# Altium schematic sheet Sheet1.SchDoc(Sheet1)
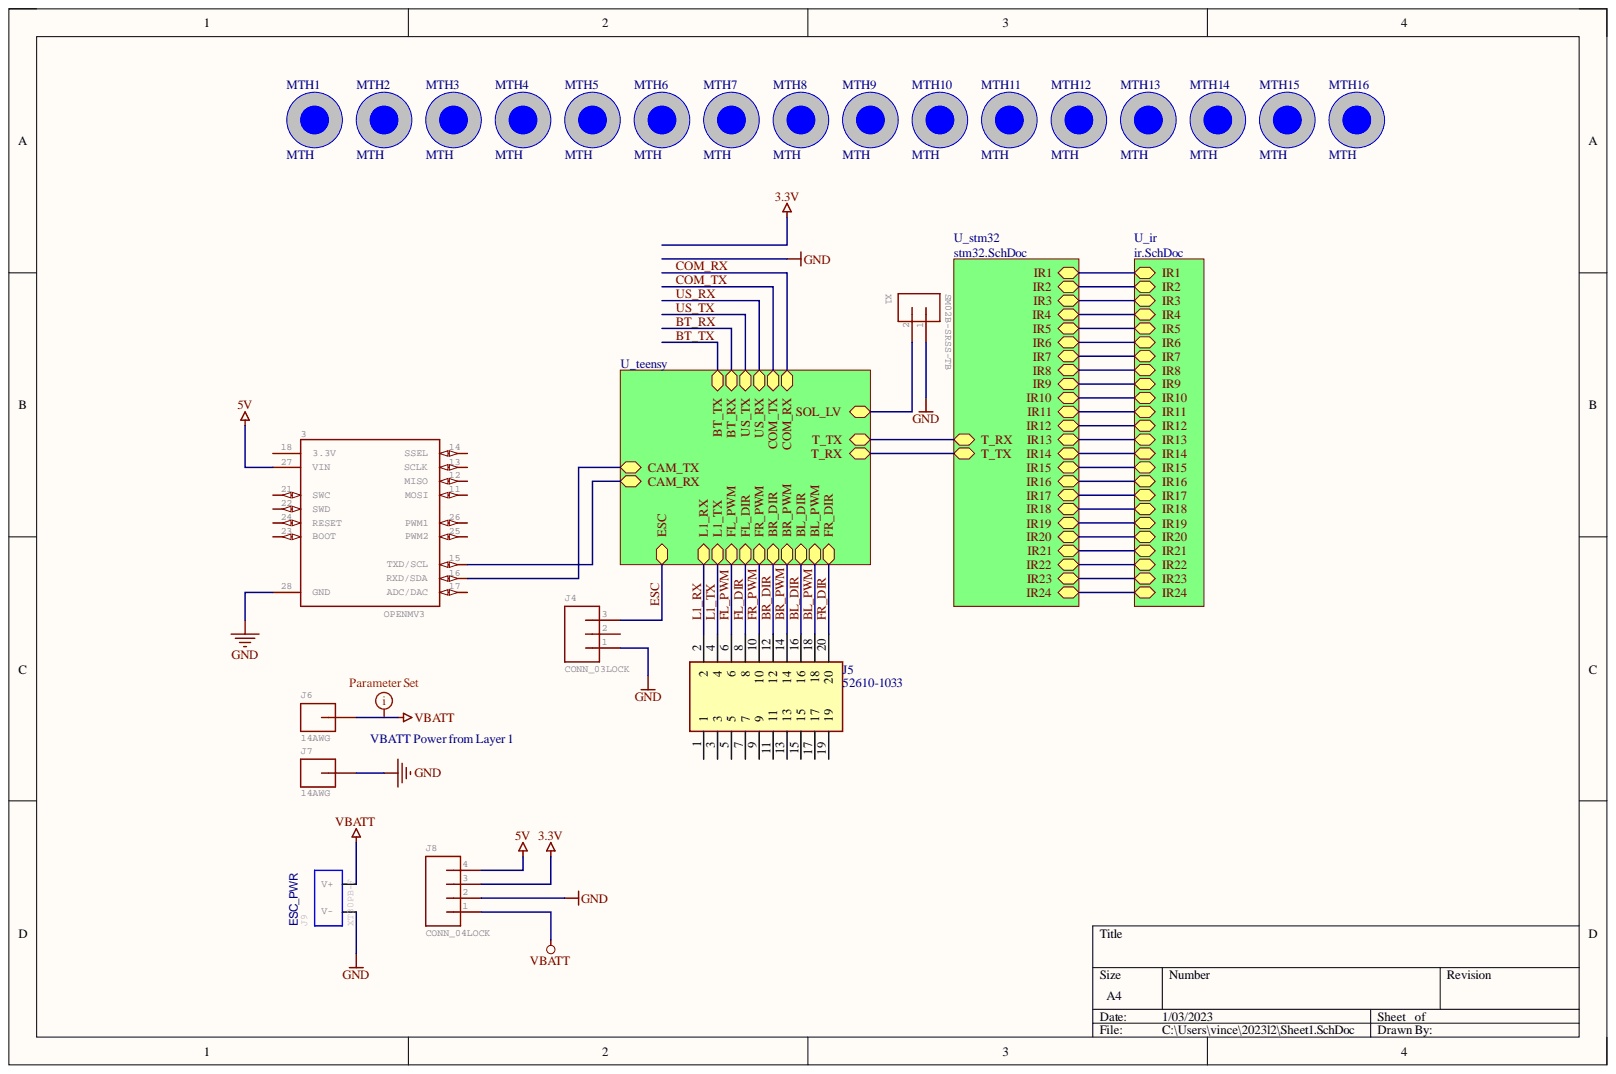
[diagram p0: Sheet1.SchDoc]

## Parts
3  OPENMV3  [OPENMV3]
J4  CONN_03LOCK  [1X03_LOCK]  (value not drawn)
J5  52610-1033  [526101033]
J6  14AWG  [14AWG]
J7  14AWG  [14AWG]
J8  CONN_04LOCK  [1X04_LOCK]  (value not drawn)
J9  XT30PB-F  [XT30PB-F]
MTH1  MTH  [New footprint 1]
MTH2  MTH  [New footprint 1]
MTH3  MTH  [New footprint 1]
MTH4  MTH  [New footprint 1]
MTH5  MTH  [New footprint 1]
MTH6  MTH  [New footprint 1]
MTH7  MTH  [New footprint 1]
MTH8  MTH  [New footprint 1]
MTH9  MTH  [New footprint 1]
MTH10  MTH  [New footprint 1]
MTH11  MTH  [New footprint 1]
MTH12  MTH  [New footprint 1]
MTH13  MTH  [New footprint 1]
MTH14  MTH  [New footprint 1]
MTH15  MTH  [New footprint 1]
MTH16  MTH  [New footprint 1]
X1  SM02B-SRSS-TB  [SM02B-SRSS-TB]

## Nets
3.3V: J8.3
5V: 3.27(VIN) J8.4
GND: 3.28(GND) J4.1 J7.P$1 J8.2 J9.- X1.1
VBATT: J6.P$1 J8.1 J9.+
BL_DIR: J5.16
BL_PWM: J5.18
BR_DIR: J5.12
BR_PWM: J5.14
ESC: J4.3
FL_DIR: J5.8
FL_PWM: J5.6
FR_DIR: J5.20
FR_PWM: J5.10
L1_RX: J5.2
L1_TX: J5.4
Net3_11: 3.11(MOSI)
Net3_12: 3.12(MISO)
Net3_13: 3.13(SCLK)
Net3_14: 3.14(SSEL)
Net3_15: 3.15(TXD/SCL)
Net3_16: 3.16(RXD/SDA)
Net3_17: 3.17(ADC/DAC)
Net3_18: 3.18(3.3V)
Net3_21: 3.21(SWC)
Net3_22: 3.22(SWD)
Net3_23: 3.23(BOOT)
Net3_24: 3.24(RESET)
Net3_25: 3.25(PWM2)
Net3_26: 3.26(PWM1)
NetJ4_2: J4.2
NetJ5_1: J5.1
NetJ5_3: J5.3
NetJ5_5: J5.5
NetJ5_7: J5.7
NetJ5_9: J5.9
NetJ5_11: J5.11
NetJ5_13: J5.13
NetJ5_15: J5.15
NetJ5_17: J5.17
NetJ5_19: J5.19
NetX1_2: X1.2
BT_RX:
BT_TX:
COM_RX:
COM_TX:
IR1:
IR2:
IR3:
IR4:
IR5:
IR6:
IR7:
IR8:
IR9:
IR10:
IR11:
IR12:
IR13:
IR14:
IR15:
IR16:
IR17:
IR18:
IR19:
IR20:
IR21:
IR22:
IR23:
IR24:
T_RX:
US_RX:
US_TX:

## Library data of the parts
3 = CMP-085-000000-11: Camera; GateName_1: G$1; Component Kind: Standard; Component Type: Standard; Design Item ID: OPENMV3; DeviceSetName: OPENMV3; LibraryName: openmv; Package: OPENMV3; Pin Count: 16; SymbolName_1: OPENMV3; Library Name: Hwa Chong Institution
J4 = CMP-027-000002-2: DeviceName: LOCK; GateName_1: J$1; Component Kind: Standard; Component Type: Standard; Design Item ID: CONN_03LOCK; DeviceSetName: CONN_03; LibraryName: SparkFun-Connectors; Package: 1X03_LOCK; Pin Count: 3; Source: SparkFun-Connectors.SCHLIB; SymbolName_1: CONN_03; Library Name: Hwa Chong Institution
J5 = CMP-096-000003-1: FFC & FPC Connectors 1.0 FPC ZIF ST 10Ckt Sn-Ag-Bi; Manufacturer_Name: Molex; Component Kind: Standard; Datasheet Link: https://www.mouser.com/datasheet/2/276/0526101033_FFC_FPC_CONNECTORS-294979.pdf; Design Item ID: 52610-1033; Height: 7.45mm; Manufacturer_Part_Number: 52610-1033; Mouser Part Number: 538-52610-1033; Mouser Price/Stock: https://www.mouser.co.uk/ProductDetail/Molex/52610-1033?qs=rw2VfpiI%2FsPpjB%252BOP20huA%3D%3D; Source: SamacSys.SchLib; Library Name: Hwa Chong Institution
J6 J7 = CMP-080-000001-1: GateName_1: G$1; SymbolName_1: CONN_01; Component Kind: Standard; Component Type: Standard; Design Item ID: 14AWG; DeviceSetName: 14AWG; LibraryName: MY-LIBRARY; Package: 14AWG; Pin Count: 1; Source: MY-LIBRARY.SCHLIB; Library Name: Hwa Chong Institution
J8 = CMP-027-000001-1: DeviceName: LOCK; GateName_1: J1; PROD_ID: CONN-09696; Component Kind: Standard; Component Type: Standard; Design Item ID: CONN_04LOCK; DeviceSetName: CONN_04; LibraryName: SparkFun-Connectors; Package: 1X04_LOCK; Pin Count: 4; Source: SparkFun-Connectors.SCHLIB; SymbolName_1: CONN_04; Library Name: Hwa Chong Institution
J9 = CMP-071-000005-3: XT30; DeviceName: PB-F; GateName_1: G$1; SymbolName_1: POWER_CONSORT; Component Kind: Standard; Component Type: Standard; Design Item ID: XT30PB-F; DeviceSetName: XT30; LibraryName: JH; Package: XT30PB-F; Pin Count: 2; Source: JH.SCHLIB; Library Name: Hwa Chong Institution
MTH1-MTH16 = CMP-00028-00002-1: Normal M3 MTH; Component Kind: Standard; Library Name: Hwa Chong Institution
X1 = CMP-087-000000-2: Connector; DeviceName: -TB; GateName_1: G$1; SymbolName_1: M_1R02; Component Kind: Standard; Component Type: Standard; Design Item ID: SM02B-SRSS-TB; DeviceSetName: SM02B-SRSS; LibraryName: con-JST SH; Package: SM02B-SRSS-TB; Pin Count: 2; Source: con-JST SH.SCHLIB; Library Name: Hwa Chong Institution
